# Altium schematic sheet AS025-HVPAK PMOD.SchDoc
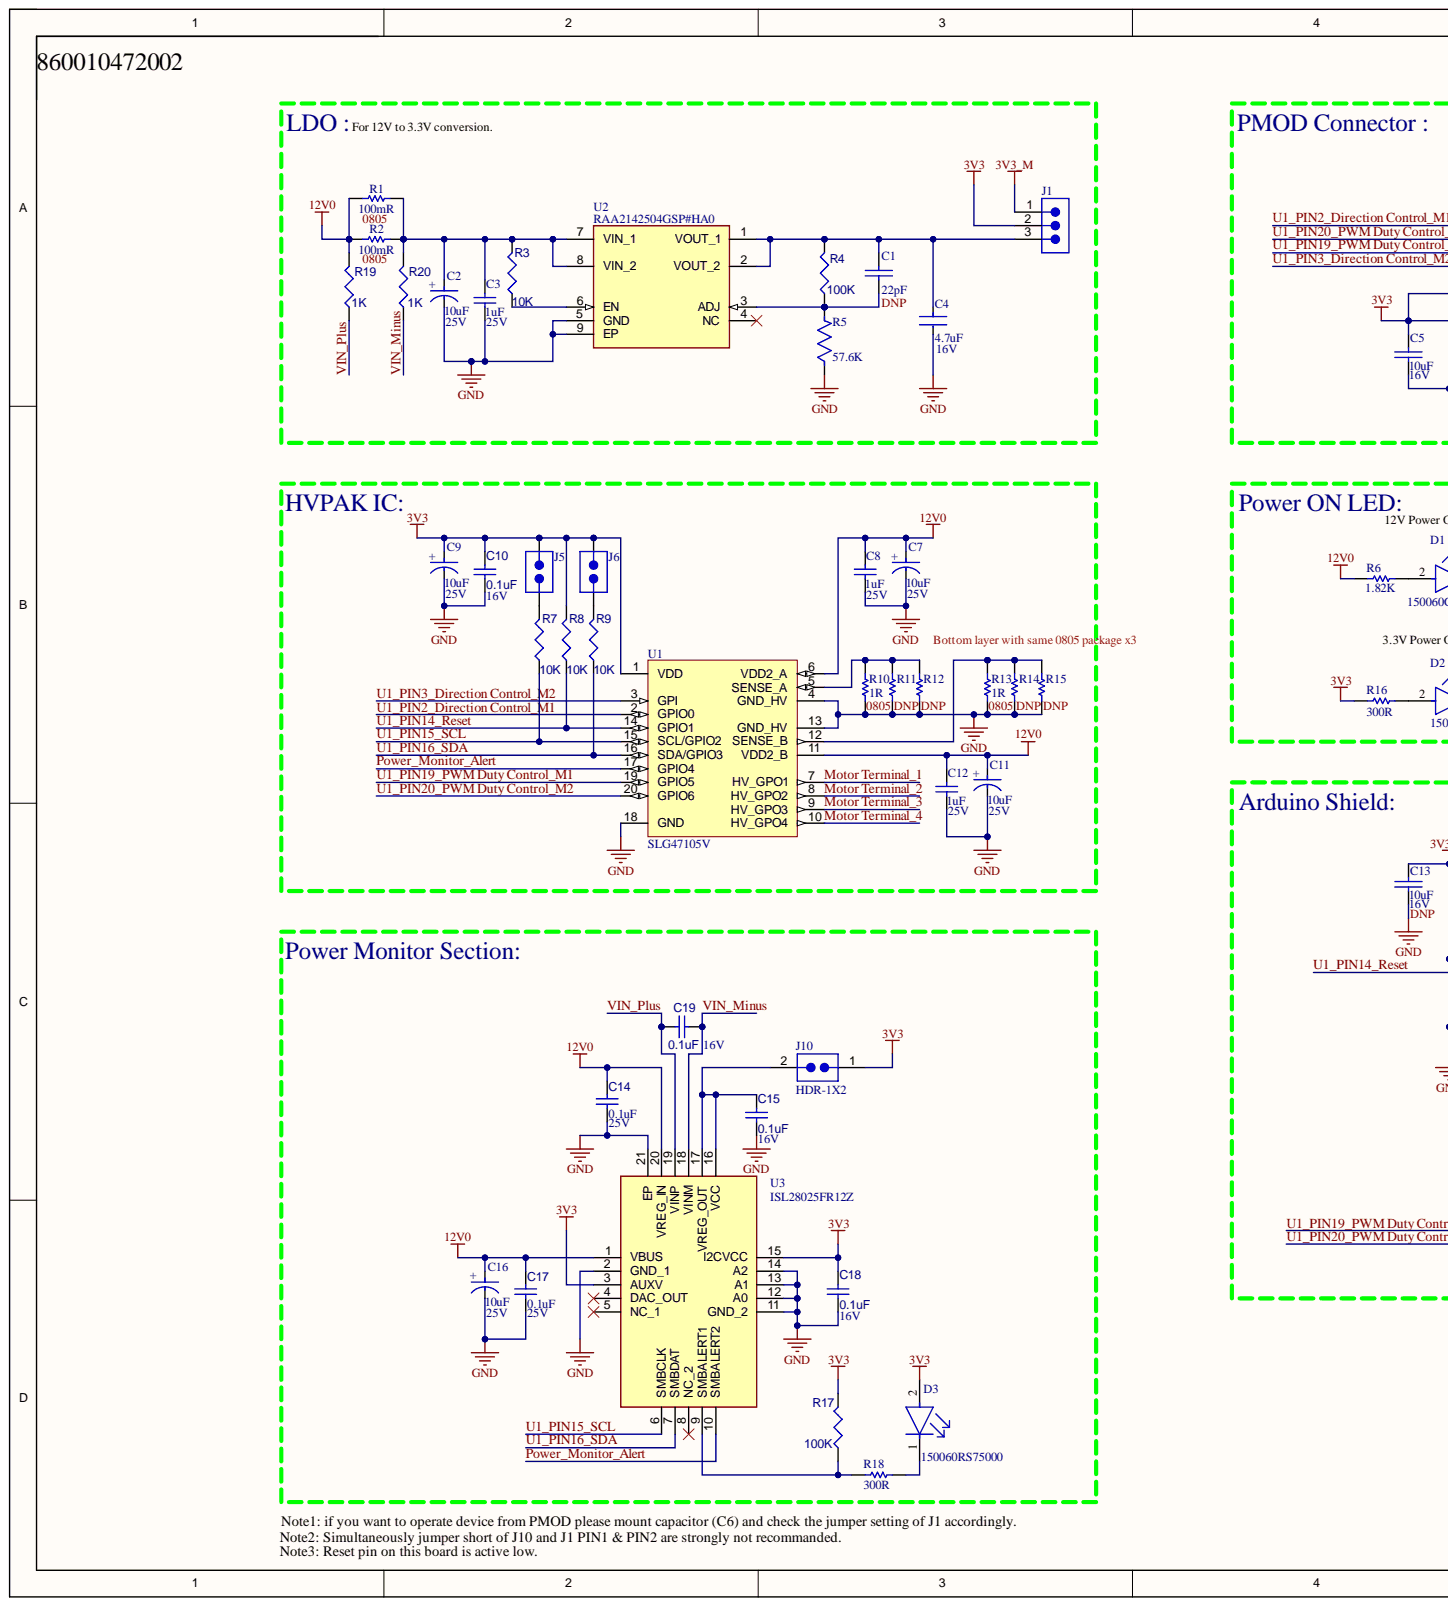
[diagram p0: AS025-HVPAK PMOD.SchDoc, left]
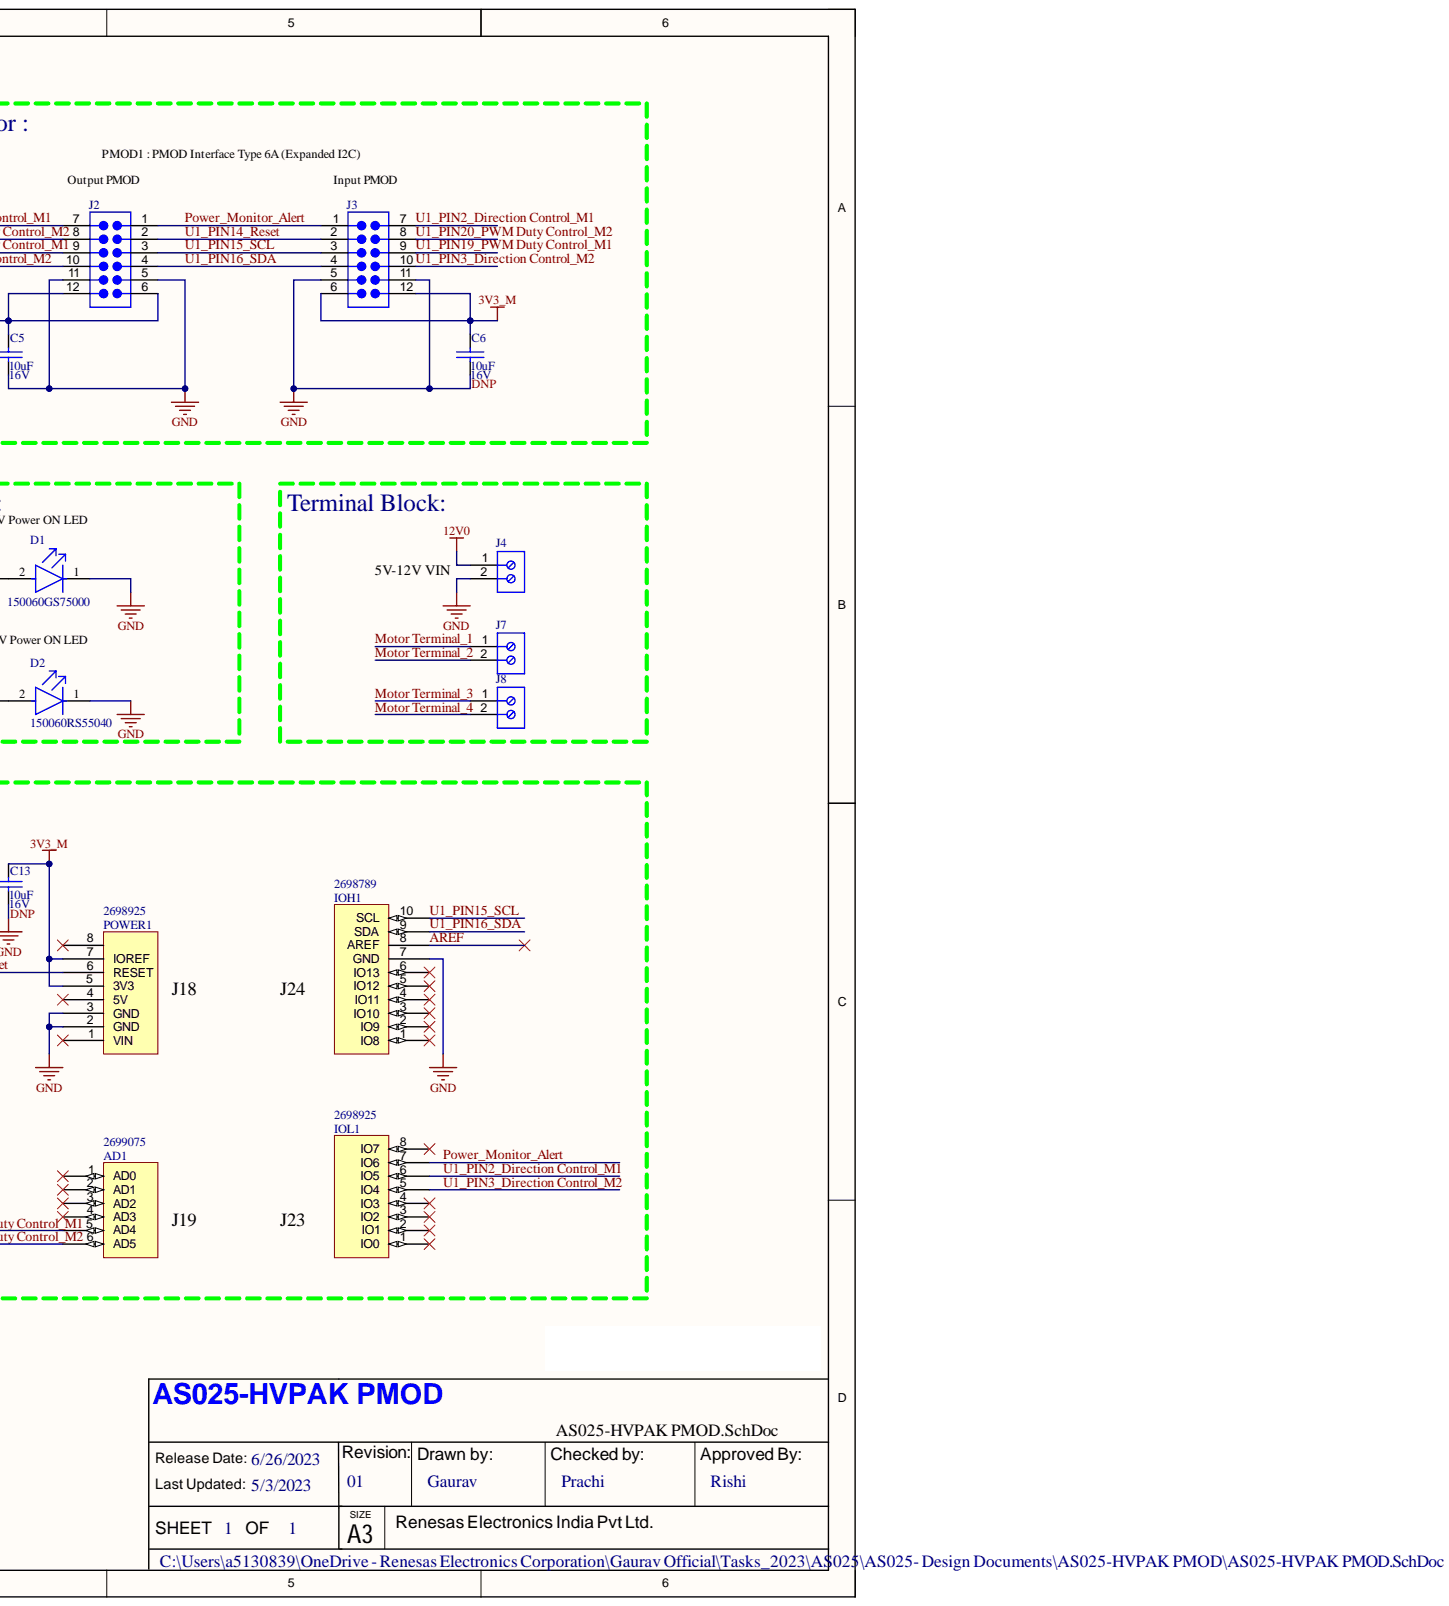
[diagram p1: AS025-HVPAK PMOD.SchDoc, right]

## Parts
AD1  AD  Supplier Part Number 4: 2699075  [Header 6x1 8.5mm]
C1  22pF  ASSY Note: DNP  [C0603]
C2  10uF  Rated DC Voltage (URdc): 25V  [FP-SC-MFG]
C3  1uF  Voltage Rating: 25V  [CAPC1608X90X40ML10T20]
C4  4.7uF  Voltage: 16V  [FP-C1608-080-0_1-IPC_C]
C5  10uF  Voltage Rating: 16V  [CAPC1608X10N]
C6  10uF  ASSY Note: DNP  Voltage Rating: 16V  [CAPC1608X10N]
C7  10uF  Rated DC Voltage (URdc): 25V  [FP-SC-MFG]
C8  1uF  Voltage Rating: 25V  [CAPC1608X90X40ML10T20]
C9  10uF  Rated DC Voltage (URdc): 25V  [FP-SC-MFG]
C10  0.1uF  Voltage Rating: 16V  [CAPC1608X90X35LL15T15]
C11  10uF  Rated DC Voltage (URdc): 25V  [FP-SC-MFG]
C12  1uF  Voltage Rating: 25V  [CAPC1608X90X40ML10T20]
C13  10uF  ASSY Note: DNP  Voltage Rating: 16V  [CAPC1608X10N]
C14  value 0.1uF  Voltage Rating: 25V  [CAPC1608X90X35LL15T15]  (hidden comment: CC0603KPX7R8BB104)
C15  0.1uF  Voltage Rating: 16V  [CAPC1608X90X35LL15T15]
C16  10uF  Rated DC Voltage (URdc): 25V  [FP-SC-MFG]
C17  value 0.1uF  Voltage Rating: 25V  [CAPC1608X90X35LL15T15]  (hidden comment: CC0603KPX7R8BB104)
C18  0.1uF  Voltage Rating: 16V  [CAPC1608X90X35LL15T15]
C19  0.1uF  Voltage Rating: 16V  [CAPC1608X90X35LL15T15]
D1  150060GS75000  [FP-150060GS75000-MFG]
D2  150060RS55040  [FP-150060RS55040-MFG]
D3  150060RS75000  [FP-150060RS75000-MFG]
IOH1  IOH  Supplier Part Number 3: 2698789  [Header 10x1 8.5mm]
IOL1  IOL  Supplier Part Number 4: 2698925  [Header 8x1 8.5mm]
J1  CON-000056-02  [FCI_77311-818-03LF]  (value not drawn)
J2  CON-000050-01_P  [SAMTEC_SSW-106-02-F-D-RA-PMOD]  (value not drawn)
J3  PMOD_6X2_RA_TH_Header  [PMOD-CONN2X6-RA-TH-HDR]  (value not drawn)
J4  691210910002  [691210910002]  (value not drawn)
J5  HDR-1X2  [HDR-1X2-FTS]  (value not drawn)
J6  HDR-1X2  [HDR-1X2-FTS]  (value not drawn)
J7  691210910002  [691210910002]  (value not drawn)
J8  691210910002  [691210910002]  (value not drawn)
J10  HDR-1X2  [HDR-1X2-FTS]
POWER1  Supplier Part Number 4: 2698925  [Header 8x1 8.5mm]  (hidden comment: POWER_UNO)
R1  100mR  [RESC2013X70X40LL20T20]
R2  100mR  Case/Package: 0805  [RESC2013X70X40LL20T20]
R3  10K  [RESC1608X55X25ML10T15]
R4  100K  [RESC1608X55X25ML10T15]
R5  57.6K  [FP-RT0603-MFG]
R6  1.82K  [RESC1608X55X25NL10T15]
R7  10K  [RESC1608X55X25ML10T15]
R8  10K  [RESC1608X55X25ML10T15]
R9  10K  [RESC1608X55X25ML10T15]
R10  1R  Case/Package: 0805  [RESC2013X60X35NL10T20]
R11  1R  ASSY Note: DNP  Case/Package: 0805  [RESC2013X60X35NL10T20]
R12  1R  ASSY Note: DNP  [RESC2013X60X35NL10T20]
R13  1R  Case/Package: 0805  [RESC2013X60X35NL10T20]
R14  1R  ASSY Note: DNP  Case/Package: 0805  [RESC2013X60X35NL10T20]
R15  1R  ASSY Note: DNP  Case/Package: 0805  [RESC2013X60X35NL10T20]
R16  300R  [RESC1608X55X25LL10T15]
R17  100K  [RESC1608X55X25ML10T15]
R18  300R  [RESC1608X55X25LL10T15]
R19  1K  [RESC1608X55X25ML10T15]
R20  1K  [RESC1608X55X25ML10T15]
U1  SLG47105V  [STQFN-20-2.0X3.0-C]
U2  RAA2142504GSP#HA0  [RAA2142504GSPHA0]
U3  ISL28025FR12Z  [QFN50P400X400X100-21N - duplicate]

## Nets
3V3: C5.1 C9.2 C10.2 C18.2 D3.2 J1.2 J2.6 J2.12 J5.1 J6.1 J10.1 R8.2 R16.1 R17.2 U1.1(VDD) U3.3(AUXV) U3.15(I2CVCC)
3V3_M: C6.1 C13.1 J1.1 J3.6 J3.12 POWER1.5(3V3) POWER1.7(IOREF)
12V0: C7.2 C8.2 C11.2 C12.2 C14.2 C16.2 C17.2 J4.1 R1.1 R2.1 R6.1 R19.2 U1.6(VDD2_A) U1.11(VDD2_B) U3.1(VBUS) U3.20(VREG_IN)
GND: C2.1 C3.1 C4.1 C5.2 C6.2 C7.1 C8.1 C9.1 C10.1 C11.1 C12.1 C13.2 C14.1 C15.1 C16.1 C17.1 C18.1 D1.1 D2.1 IOH1.7(GND) J2.5 J2.11 J3.5 J3.11 J4.2 POWER1.2(GND) POWER1.3(GND) R5.1 R10.1 R11.1 R12.1 R13.1 R14.1 R15.1 U1.4(GND_HV) U1.13(GND_HV) U1.18(GND) U2.5(GND) U2.9(EP) U3.2(GND_1) U3.11(GND_2) U3.12(A0) U3.13(A1) U3.14(A2) U3.21(EP)
AREF: IOH1.8(AREF)
Motor Terminal_1: J7.1 U1.7(HV_GPO1)
Motor Terminal_2: J7.2 U1.8(HV_GPO2)
Motor Terminal_3: J8.1 U1.9(HV_GPO3)
Motor Terminal_4: J8.2 U1.10(HV_GPO4)
Power_Monitor_Alert: IOL1.7(IO6) J2.1 J3.1 U1.17(GPIO4) U3.10(SMBALERT2)
U1_PIN2_Direction Control_M1: IOL1.6(IO5) J2.7 J3.7 U1.2(GPIO0)
U1_PIN3_Direction Control_M2: IOL1.5(IO4) J2.10 J3.10 U1.3(GPI)
U1_PIN14_Reset: J2.2 J3.2 POWER1.6(RESET) R8.1 U1.14(GPIO1)
U1_PIN15_SCL: IOH1.10(SCL) J2.3 J3.3 R7.1 U1.15(SCL/GPIO2) U3.6(SMBCLK)
U1_PIN16_SDA: IOH1.9(SDA) J2.4 J3.4 R9.1 U1.16(SDA/GPIO3) U3.7(SMBDAT)
U1_PIN19_PWM Duty Control_M1: AD1.5(AD4) J2.9 J3.9 U1.19(GPIO5)
U1_PIN20_PWM Duty Control_M2: AD1.6(AD5) J2.8 J3.8 U1.20(GPIO6)
VIN_Minus: C19.1 R20.1 U3.18(VINM)
VIN_Plus: C19.2 R19.1 U3.19(VINP)
NetC1_1: C1.1 C4.2 J1.3 R4.2 U2.1(VOUT_1) U2.2(VOUT_2)
NetC1_2: C1.2 R4.1 R5.2 U2.3(ADJ)
NetC2_2: C2.2 C3.2 R1.2 R2.2 R3.2 R20.2 U2.7(VIN_1) U2.8(VIN_2)
NetC15_2: C15.2 J10.2 U3.16(VCC) U3.17(VREG_OUT)
NetD1_2: D1.2 R6.2
NetD2_2: D2.2 R16.2
NetD3_1: D3.1 R18.2
NetJ5_2: J5.2 R7.2
NetJ6_2: J6.2 R9.2
NetR3_1: R3.1 U2.6(EN)
NetR10_2: R10.2 R11.2 R12.2 U1.5(SENSE_A)
NetR13_2: R13.2 R14.2 R15.2 U1.12(SENSE_B)
NetR17_1: R17.1 R18.1 U3.9(SMBALERT1)

Not connected: AD1 1(AD0) 2 3(AD2) 4(AD3); IOH1 1(IO8) 2(IO9) 3(IO10) 4(IO11) 5(IO12) 6(IO13); IOL1 1(IO0) 2(IO1) 3(IO2) 4(IO3) 8(IO7); POWER1 1(VIN) 4(5V) 8; U2 4(NC); U3 4(DAC_OUT) 5(NC_1) 8(NC_2)

## Library data of the parts
AD1 = AD: 6 Position Receptacle Connector 0.100" (2.54mm) Through Hole Gold; Manufacturer 1: Samtec; Manufacturer Part Number 1: SSQ-106-03-G-S; Case/Package: TH (6-POS, P-2.54mm); Component Kind: Standard; Library Name: Header.SchLib; Supplier 1: Digi-Key; Supplier 2: Arrow; Supplier 3: Arrow; Supplier 4: Arrow; Supplier Part Number 1: SAM1198-06-ND; Supplier Part Number 2: 2699089; Supplier Part Number 3: 2699088
C1 = CMP-043-000029-2: Capacitor, 22pF, 25V, SM 0603; Manufacturer 1: Kemet; Manufacturer Part Number 1: C0603C220K3GACTU; Detailed Description: CAP CER 22PF 25V C0G/NP0 0603; Case/Package: 0603; Component Kind: Standard; ComponentLink1Description: Digi-key Part Page; ComponentLink1URL: https://www.digikey.com/en/products/detail/kemet/C0603C180K3GACTU/2200061; Design Item ID: CI-22pF-25V-COG-NPO-SR-KMT; Library Name: Renesas Global; Part: 22pF, 25V; Revision: 1; Source: R_capacitors.SchLib; Type: Capacitor
C2 C7 C9 C11 C16 = CMP-05427-002131-1: CAP ALUM 10UF 20% 25V SMD; Manufacturer 1: Panasonic; Manufacturer Part Number 1: EEE-1EA100SR; Capacitor Type: Aluminium; Lead Length: 2.2mm; Leakage Current: 3uA; Life (Hours): 2000Hour; Polarity: Polarized; Ripple Current: 28mA; Tan Delta: 0.14; Capacitance: 10uF; Case/Package: Radial; Category: Aluminum Electrolytic Capacitors; Component Kind: Standard; ComponentLink1Description: https://datasheet.ciiva.com:443/pdfs/VipMasterIC/IC/PANA/PANA-S-A0009615203/PANA-S-A0009615203-1.pdf?src-supplier=IHS+Markit; ComponentLink1URL: https://datasheet.ciiva.com:443/pdfs/VipMasterIC/IC/PANA/PANA-S-A0009615203/PANA-S-A0009615203-1.pdf?src-supplier=IHS+Markit; ComponentLink2Description: https://datasheet.ciiva.com/11778/0900766b8078636e-11778389.pdf?src-supplier=Rs; ComponentLink2URL: https://datasheet.ciiva.com/11778/0900766b8078636e-11778389.pdf?src-supplier=Rs; ComponentLink3Description: https://datasheet.ciiva.com/24069/aba0000ce2-40801-24069004.pdf?src-supplier=Mouser; ComponentLink3URL: https://datasheet.ciiva.com/24069/aba0000ce2-40801-24069004.pdf?src-supplier=Mouser; ComponentLink4Description: https://datasheet.ciiva.com/14604/70255710-14604074.pdf?src-supplier=Allied+Electronics; ComponentLink4URL: https://datasheet.ciiva.com/14604/70255710-14604074.pdf?src-supplier=Allied+Electronics; ComponentLink5Description: https://datasheet.ciiva.com/14599/70255710-14599433.pdf?src-supplier=Allied+Electronics; ComponentLink5URL: https://datasheet.ciiva.com/14599/70255710-14599433.pdf?src-supplier=Allied+Electronics; ComponentLink6Description: https://datasheet.ciiva.com/43485/aba0000ce2-43485611.pdf?src-supplier=Farnell; ComponentLink6URL: https://datasheet.ciiva.com/43485/aba0000ce2-43485611.pdf?src-supplier=Farnell; ComponentLink7Description: https://datasheet.ciiva.com/16432/aba0000ce2-16432888.pdf?src-supplier=Future+Electronics; ComponentLink7URL: https://datasheet.ciiva.com/16432/aba0000ce2-16432888.pdf?src-supplier=Future+Electronics; ComponentLink8Description: https://datasheet.ciiva.com/2985/aba0000ce2-2985103.pdf?src-supplier=Avnet; ComponentLink8URL: https://datasheet.ciiva.com/2985/aba0000ce2-2985103.pdf?src-supplier=Avnet; ComponentLink9Description: https://datasheet.ciiva.com/30215/11aba0000ce2-30215179.pdf?src-supplier=Onlinecomponents.com; ComponentLink9URL: https://datasheet.ciiva.com/30215/11aba0000ce2-30215179.pdf?src-supplier=Onlinecomponents.com; Construction: Cylindrical; Contact Plating: Tin; Diameter: 5mm; Dielectric Material: Aluminium; Height: 5.4mm; Height - Seated (Max): 0.213inch; Lead Pitch: 1.5mm; Length: 5.5mm; Library Name: Altium Content Vault; Max Operating Temperature: 85°C; Min Operating Temperature: -40°C; Mount: Surface Mount; Negative Tolerance: 20%; Number of Pins: 2; Package Quantity: 1000; Package Shape: Cylindrical; Package Style: SMTMeter; Packaging: Tape and Reel; Positive Tolerance: 20%; Pricing 1: 1=0.39000, 10=0.26900, 50=0.18900, 100=0.16200, 1000=0.10200, 10000=0.10200 (USD); Radiation Hardening: No; Ratings: AEC-Q200; Reach SVHC Compliant: Yes; Ripple Current (AC): 28mA; RoHS: Yes; RoHS Compliant: Yes; Series: S; Size Code: 2121; Stock 1: 157; Supplier 1: Mouser; Supplier Part Number 1: 667-EEE-1EA100SR; Termination: SMD/SMT; Tolerance: 20%; Voltage: 25V; Voltage Rating: 25V; Voltage Rating (DC): 25V; Width: 5.3mm
C3 C8 C12 = CMP-001-00005-1: 1 µF ±10% 25V Ceramic Capacitor X7R 0603 (1608 Metric); Manufacturer 1: Yageo; Manufacturer Part Number 1: CC0603KRX7R8BB105; Capacitance: 1µF; Capacitor Type: Ceramic; Case/Package: 0603; Component Kind: Standard; Library Name: Renesas Global; Max Operating Temperature: 125°C; Min Operating Temperature: -55°C; Mounting Technology: SM; RoHS Compliant: True; Tolerance: 10%
C4 = CMP-2000-04981-2: Multilayer Ceramic Capacitors 4.7μF ±10% 16V X5R SMD 0603; Manufacturer 1: TDK Corporation; Manufacturer Part Number 1: C1608X5R1C475K080AC; Capacitance: 4.7uF; Case Code (Imperial): 0603; Case Code (Metric): 1608; Case/Package: 0603; Component Kind: Standard; Construction: Flat; Depth: 0.8mm; Dielectric: X5R; Dielectric Material: Ceramic; Height: 0.8mm; Lead Free: Lead Free; Length: 1.6mm; Library Name: Altium Content Vault; Max Operating Temperature: 85°C; Min Operating Temperature: -55°C; Mount: Surface Mount; Package Quantity: 1; Packaging: Tape and Reel; REACH SVHC: No SVHC; RoHS Compliant: Yes; Series: C; Termination: SMD/SMT; Thickness: 0.035inch; Tolerance: 10%; Voltage Rating: 16V; Voltage Rating (DC): 16V; Weight: 7.1E-05oz; Width: 0.031inch
C5 C6 C13 = CMP-043-000020-2: 10 µF ±10% 16V Ceramic Capacitor X5R 0603 (1608 Metric); Manufacturer 1: Yageo; Manufacturer Part Number 1: CC0603KRX5R7BB106; Detailed Description: Capacitor, 10uF, 16V, SM 0603, Multilayer Ceramic, X5R, RoHS; Case/Package: 0603; Component Kind: Standard; Design Item ID: CI-10uF-16V-X5R-SR-MRT; Library Name: Renesas Global; Package: 0603; Part: 10uF; Revision: 1; Source: R_capacitors.SchLib; Tolerance: 10%; Type: Capacitor
C10 C15 C18 C19 = CMP-002-000055-1: 0.1 µF ±10% 16V Ceramic Capacitor X7R 0603 (1608 Metric); Manufacturer 1: YAGEO; Manufacturer Part Number 1: CC0603KPX7R7BB104; Capacitor Type: Ceramic; Case/Package: 0603; Component Kind: Standard; Design Item ID: CC0603KPX7R7BB104; Library Name: Renesas Global; Max Operating Temperature: 125°C; Min Operating Temperature: -55°C; Mounting Technology: SM; Pins: 2; RoHS Compliant: True; Tolerance: 10%; Value: 0.1uF; Source: RAA211250 SYNC BUCK REGULATOR.SchLib
C14 C17 = CMP-002-000056-1: 0.1 µF ±10% 25V Ceramic Capacitor X7R 0603 (1608 Metric); Manufacturer 1: YAGEO; Capacitor Type: Ceramic; Case/Package: 0603; Component Kind: Standard; Design Item ID: CC0603KPX7R8BB104; Library Name: Renesas Global; Manufacturer Part Number 1: CC0603KPX7R8BB104; Max Operating Temperature: 125°C; Min Operating Temperature: -55°C; Mounting Technology: SM; Pins: 2; RoHS Compliant: True; Tolerance: 10%; Source: RAA211250 SYNC BUCK REGULATOR.SchLib
D1 = CMP-1426-00002-2: LED GREEN CLEAR 0603 SMD; Manufacturer 1: Wurth Electronics; Dominant Wavelength: 520nm; Forward Current: 30mA; Forward Voltage: 3.2V; Lens Color: Colorless; Lens Size: 0.7mm; Luminous Intensity: 430mcd; Number of Functions: 1; Optoelectronic Device Type: SINGLE COLOR LED; Shape: Rectangular; Test Current: 20mA; Viewing Angle: 140°; Case Code (Imperial): 0603; Case Code (Metric): 1608; Case/Package: 0603; Category: LEDs; Color: Green; Component Kind: Standard; ComponentLink1Description: https://datasheet.ciiva.com:443/pdfs/VipMasterIC/IC/MIDC/MIDC-S-A0007790169/MIDC-S-A0007790169-1.pdf?src-supplier=IHS+Markit; ComponentLink1URL: https://datasheet.ciiva.com:443/pdfs/VipMasterIC/IC/MIDC/MIDC-S-A0007790169/MIDC-S-A0007790169-1.pdf?src-supplier=IHS+Markit; ComponentLink2Description: https://datasheet.ciiva.com/29562/150060gs75000-29562868.pdf?src-supplier=Digi-Key; ComponentLink2URL: https://datasheet.ciiva.com/29562/150060gs75000-29562868.pdf?src-supplier=Digi-Key; ComponentLink3Description: https://datasheet.ciiva.com/29279/759427-da-01-en-chipled-0603-w-kl-gruen-150060gs75000-29279201.pdf?src-supplier=Conrad; ComponentLink3URL: https://datasheet.ciiva.com/29279/759427-da-01-en-chipled-0603-w-kl-gruen-150060gs75000-29279201.pdf?src-supplier=Conrad; ComponentLink4Description: https://datasheet.ciiva.com/29562/150060gs75000-29562869.pdf?src-supplier=Digi-Key; ComponentLink4URL: https://datasheet.ciiva.com/29562/150060gs75000-29562869.pdf?src-supplier=Digi-Key; ComponentLink5Description: https://datasheet.ciiva.com/29562/150060gs75000-29562870.pdf?src-supplier=Digi-Key; ComponentLink5URL: https://datasheet.ciiva.com/29562/150060gs75000-29562870.pdf?src-supplier=Digi-Key; Forward Current-Max: 30mA; Height: 0.8mm; Lead Free: Lead Free; Length: 1.6mm; Lens Style: Clear; Lens Transparency: Clear; Library Name: Altium Content Vault; Luminous Intensity-Nom: 430mcd; Manufacturer Part Number 1: 150060GS75000; Max Operating Temperature: 85°C; Min Operating Temperature: -40°C; Mount: Surface Mount; Number of Pins: 2; Overall Height: 0.7mm; Package Quantity: 1; Packaging: Cut Tape; Pricing 1: 100=0.00107, 500=0.08300, 1000=0.07900, 2000=0.07500, 4000=0.07100 (GBP); Reach SVHC Compliant: Yes; RoHS: Yes; RoHS Compliant: Yes; Series: WL-SMCW; Size: 1.1mm; Stock 1: 12800; Supplier 1: Rs; Supplier Part Number 1: 8154209; Terminal Pitch: 1.6mm; Wavelength: 520nm; Width: 0.8mm
D2 = CMP-207942-000007-1: LED RED DIFFUSED 0603 SMD; Manufacturer 1: Würth Elektronik; Manufacturer Part Number 1: 150060YS55040; Dominant Wavelength: 624nm; Forward Voltage: 2V; Lens Size: 0.8mm; Lens Style: Flat; Luminous Intensity: 90mcd; Test Current: 20mA; Viewing Angle: 140°; Case Code (Imperial): 0603; Case Code (Metric): 1608; Case/Package: 0603; Color: Red; Component Kind: Standard; Height: 0.5mm; Length: 1.6mm; Lens Transparency: Diffused; Library Name: Altium Content Vault; Mount: Surface Mount; Packaging: Cut Tape; RoHS Compliant: No; Width: 0.8mm
D3 = CMP-1426-00001-2: LED RED CLEAR 0603 SMD; Manufacturer 1: Wurth Electronics; Dominant Wavelength: 625nm; Forward Current: 30mA; Forward Voltage: 2V; Lens Color: Colorless; Lens Size: 0.7mm; Luminous Intensity: 250mcd; Test Current: 20mA; Viewing Angle: 140°; Case Code (Imperial): 0603; Case Code (Metric): 1608; Case/Package: 0603; Color: Red; Component Kind: Standard; Height: 0.8mm; Length: 1.6mm; Lens Style: Clear; Lens Transparency: Clear; Library Name: Altium Content Vault; Manufacturer Part Number 1: 150060RS75000; Max Operating Temperature: 85°C; Min Operating Temperature: -40°C; Mount: Surface Mount; Package Quantity: 1; Packaging: Cut Tape; RoHS Compliant: Yes; Series: WL-SMCW; Wavelength: 625nm; Width: 0.8mm
IOH1 = IOH: 10 Position Receptacle Connector 0.100" (2.54mm) Through Hole Gold; Manufacturer 1: Samtec; Manufacturer Part number 1: SSQ-110-03-G-S; Case/Package: TH (10-POS, P-2.54mm); Component Kind: Standard; Library Name: Header.SchLib; Supplier 1: Digi-Key; Supplier 2: Arrow; Supplier 3: Arrow; Supplier Part Number 1: SAM1198-10-ND; Supplier Part Number 2: 2698803S4475693N1004
IOL1 = IOL: 8 Position Receptacle Connector 0.100" (2.54mm) Through Hole Gold; Manufacturer 1: Samtec; Manufacturer Part Number 1: SSQ-108-03-G-S; Case/Package: TH (8-POS, P-2.54mm); Component Kind: Standard; Library Name: Header.SchLib; Supplier 1: Digi-Key; Supplier 2: Arrow; Supplier 3: Arrow; Supplier 4: Arrow; Supplier Part Number 1: SAM1198-08-ND; Supplier Part Number 2: 2698939; Supplier Part Number 3: 2698940
J1 = CMP-038-000018-1: Connector Header Through Hole 3 position 0.100" (2.54mm); Manufacturer: FCI; Manufacturer Part Number 1: 77311-818-03LF; Positions: 3; Case/Package: TH (3 POS P-2.54mm); Component Kind: Standard; Design Item ID: CON-000056-02; Library Name: Renesas Global; Manufacturer 1: FCI; Mfg: FCI; Mfg Part Number: 77311-818-03LF; Pitch: 2.54mm; PN: 77311-818-03LF; Source: Connectors.SchLib
J2 = CMP-038-000217-1: 12 Position Receptacle Connector 0.100" (2.54mm) Through Hole, Right Angle Gold; Manufacturer 1: Würth Elektronik; Manufacturer Part Number 1: 613012243121; Mfg Part Number: SSW-106-02-F-D-RA; Positions: 12; Case/Package: TH (P-2.54x2.54mm); Component Kind: Standard; Design Item ID: CON-000050-01_P; Library Name: Renesas Global; Manufacturer 2: Samtec; Manufacturer Part Number 2: SSW-106-02-F-D-RA; Pitch: 2.54mm; Source: Connectors.SchLib
J3 = CMP-024-000000-1: Connector Header Through Hole, Right Angle 12 position 0.100" (2.54mm); Manufacturer 1: Würth Elektronik; Manufacturer Part Number 1: 61301221021; Positions: 12; Case/Package: TH (P-2.54x2.54mm); Component Kind: Standard; Design Item ID: PMOD_6X2_RA_TH_Header; Library Name: Renesas Global; Manufacturer 2: Harwin; Manufacturer 3: Samtec Inc.; Manufacturer Part Number 2: M20-9950645; Manufacturer Part Number 3: TSW-106-08-F-D-RA; Pitch: 2.54mm; Source: PMOD_Conn.SchLib
J4 J7 J8 = CMP-1502-02456-1: Serie 2109 - 2.54 mm Horizontal Entry with Rising Cage Clamp WR-TBL, 2 pin; Manufacturer 1: Wurth Elektronik; Manufacturer Series: 2109; Pin Number: 2; Pitch: 2.54mm; Category: Terminal Blocks; Component Kind: Standard; ComponentLink1Description: https://datasheet.ciiva.com:443/pdfs/VipMasterIC/IC/MIDC/MIDCS07477/MIDCS07477-1.pdf?src-supplier=IHS+Markit; ComponentLink1URL: https://datasheet.ciiva.com:443/pdfs/VipMasterIC/IC/MIDC/MIDCS07477/MIDCS07477-1.pdf?src-supplier=IHS+Markit; Datasheet URL: http://katalog.we-online.de/em/datasheet/6912109100xx.pdf; Library Name: Altium Content Vault; Manufacturer Part Number 1: 691210910002; Manufacturer URL: http://www.we-online.de; Mounting Technology: THT; Operating Temperature: -40to105degC; Package Reference: 691210910002; Packaging: Box; Part Number: 691210910002; Pricing 1: 1=1.14000, 10=1.04300, 50=0.98400, 100=0.90500, 500=0.74800 (USD); Reach SVHC Compliant: Yes; RoHS: Yes; RoHS Compliant: Yes; Stock 1: 1283; Supplier 1: Digi-Key; Supplier Part Number 1: 732-691210910002-ND; Terminal and Terminal Block Type: BARRIER STRIP TERMINAL BLOCK; Publisher: WurthElektronik
J5 J6 J10 = CMP-006-000000-1: CONN HEADER VERT 2POS 1.27 MM; Manufacturer 1: Samtec Inc.; Manufacturer Part Number 1: FTS-102-01-L-S; Pitch: 1.27 mm; Case/Package: TH (P-1.27mm); Component Kind: Standard; ComponentLink1Description: Datasheet; ComponentLink1URL: http://suddendocs.samtec.com/catalog_english/fts.pdf; ComponentLink2Description: Package Specification; ComponentLink2URL: http://suddendocs.samtec.com/prints/fts-1xx-xx-x-sx-mkt.pdf; Design Item ID: HDR-1X2; Library Name: Renesas Global; Mounting Technology: Through Hole; Orientation: Vertical; PackageDescription: Shrouded Header; RoHS: True; Source: R_Misc_Conn.SchLib; Supplier 1: Digi-Key; Supplier Part Number 1: FTS-102-01-L-S-ND; Temperature Range: -55 to 125 degC
POWER1 = POWER_UNO: 8 Position Receptacle Connector 0.100" (2.54mm) Through Hole Gold; Manufacturer 1: Samtec; Manufacturer Part Number 1: SSQ-108-03-G-S; Case/Package: TH (8-POS, P-2.54mm); Component Kind: Standard; Library Name: Header.SchLib; Supplier 1: Digi-Key; Supplier 2: Arrow; Supplier 3: Arrow; Supplier 4: Arrow; Supplier Part Number 1: SAM1198-08-ND; Supplier Part Number 2: 2698939; Supplier Part Number 3: 2698940
R1 R2 = CMP-2001-00503-1: 100 mOhms ±1% 0.333W, 1/3W Chip Resistor 0805 (2012 Metric) Automotive AEC-Q200, Current Sense Thick Film; Manufacturer: Panasonic; Manufacturer Part Number: ERJ6BSFR10V; Rated Power Dissipation (P): 330mW; Rated Temperature: 70°C; Temperature Coefficient: 250ppm/°C; Category: Chip SMD Resistors; Component Kind: Standard; ComponentLink1Description: https://datasheet.ciiva.com:443/pdfs/VipMasterIC/IC/PANA/PANA-S-A0009615198/PANA-S-A0009615198-1.pdf?src-supplier=IHS+Markit; ComponentLink1URL: https://datasheet.ciiva.com:443/pdfs/VipMasterIC/IC/PANA/PANA-S-A0009615198/PANA-S-A0009615198-1.pdf?src-supplier=IHS+Markit; ComponentLink2Description: https://datasheet.ciiva.com/11818/0900766b80eefa12-11818538.pdf?src-supplier=Rs; ComponentLink2URL: https://datasheet.ciiva.com/11818/0900766b80eefa12-11818538.pdf?src-supplier=Rs; ComponentLink3Description: https://datasheet.ciiva.com/48535/erj-eng-tds-48535045.pdf?src-supplier=Distrelec; ComponentLink3URL: https://datasheet.ciiva.com/48535/erj-eng-tds-48535045.pdf?src-supplier=Distrelec; ComponentLink4Description: https://datasheet.ciiva.com/6137/391509-6137607.pdf?src-supplier=Farnell; ComponentLink4URL: https://datasheet.ciiva.com/6137/391509-6137607.pdf?src-supplier=Farnell; ComponentLink5Description: https://datasheet.ciiva.com/7944/391509-7944654.pdf?src-supplier=Element14; ComponentLink5URL: https://datasheet.ciiva.com/7944/391509-7944654.pdf?src-supplier=Element14; ComponentLink6Description: https://datasheet.ciiva.com/6137/391509-6137624.pdf?src-supplier=Farnell; ComponentLink6URL: https://datasheet.ciiva.com/6137/391509-6137624.pdf?src-supplier=Farnell; ComponentLink7Description: https://datasheet.ciiva.com/7944/391509-7944655.pdf?src-supplier=Element14; ComponentLink7URL: https://datasheet.ciiva.com/7944/391509-7944655.pdf?src-supplier=Element14; ComponentLink8Description: https://datasheet.ciiva.com/24063/aoa0000ce3-72189-24063962.pdf?src-supplier=Mouser; ComponentLink8URL: https://datasheet.ciiva.com/24063/aoa0000ce3-72189-24063962.pdf?src-supplier=Mouser; ComponentLink9Description: https://datasheet.ciiva.com/9186/aoa0000ce3-9186826.pdf?src-supplier=Avnet; ComponentLink9URL: https://datasheet.ciiva.com/9186/aoa0000ce3-9186826.pdf?src-supplier=Avnet; JESD-609 Code: e3; Library Name: Altium Content Vault; Manufacturer 1: Panasonic; Manufacturer Part Number 1: ERJ6BSFR10V; Max Operating Temperature: 125°C; Min Operating Temperature: -55°C; Mount: Surface Mount; Number of Pins: 2; Package Shape: Rectangular; Packaging: Tape and Reel; Pricing 1: 5000=0.05170 (USD); Reach SVHC Compliant: Yes; Reference Standard: AEC-Q200; Resistance: 100mR; Resistor Type: FIXED RESISTOR; RoHS: Yes; RoHS Compliant: Yes; Stock 1: 15000; Supplier 1: Arrow Electronics; Supplier Part Number 1: ERJ-6BSFR10V; Technology: METAL GLAZE/THICK FILM; Terminal Finish: Tin; Termination: Wraparound; Tolerance: 1%
  R1: Case/Package: 0805
R3 R7-R9 = CMP-009-00017-5: Chip Resistor, 10 KOhm, +/- 1%, 01 W, -55 to 155 degC, 0603 (1608 Metric); Manufacturer 1: Yageo; Manufacturer Part Number 1: RC0603FR-0710KL; Power: 100mW; Voltage Rating: 50V; Case/Package: 0603; Component Kind: Standard; Library Name: Renesas Global; Max Operating Temperature: 155°C; Min Operating Temperature: -55°C; Mounting Technology: SM; Pins: 2; RoHS Compliant: True; Tolerance: 1%; Value: 10K
R4 = CMP-009-00023-5: Chip Resistor, 100 KOhm, +/- 1%, 0.1 W, -55 to 155 degC, 0603 (1608 Metric); Manufacturer 1: Yageo; Manufacturer Part Number 1: RC0603FR-07100KL; Power: 100mW; Voltage Rating: 50V; Case/Package: 0603; Component Kind: Standard; Library Name: Renesas Global; Max Operating Temperature: 155°C; Min Operating Temperature: -55°C; Mounting Technology: SM; Pins: 2; RoHS Compliant: True; Tolerance: 1%; Value: 100K
R5 = CMP-03412-013913-1: RES SMD 57.6K OHM 1% 1/10W 0603; Manufacturer: Yageo; Manufacturer Part Number 1: RT0603FRE0757K6L; Power Rating: 0.1W; Resistance: 57.6KΩ; Temperature Coefficient: 50 ppm/°C; Tolerance: 0.01; Value: 57.6KΩ; Voltage Rating: 75V; Automotive: No; Case/Package: 0603; Category: Res; Component Kind: Standard; ComponentLink2Description: Datasheet; ComponentLink2URL: http://www.yageo.com/documents/recent/PYu-RT_1-to-0.01_RoHS_L_9.pdf; Composition: Thin Film; Device Class L1: Passive Components; Device Class L2: Resistors; Device Class L3: Chip SMD Resistors; Digikey Description: RES SMD 57.6K OHM 1% 1/10W 0603; Footprint Url: http://www.yageo.com/exep/pages/download/literatures/PYu-R_Mount_10.pdf; Height: 0.55mm; Ipc Land Pattern Name: RESC16080X45; Lead Free: Yes; Library Name: Altium Content Vault; Manufacturer 1: Yageo; Mouser Description: Thin Film Resistors - SMD 1/10W 57.6K ohm 1% 50ppm; Octopart: https://octopart.com/rt0603fre0757k6l-yageo-1478888; Package: RT0603; RoHS: TRUE; Series: RT; Temperature: -55°C to +155°C; Temperature Range High: +155°C; Temperature Range Low: -55°C
R6 = CMP-006-000025-1: 1.82 kOhms ±1% 0.1W, 1/10W Chip Resistor 0603 (1608 Metric) Moisture Resistant Thick Film; Manufacturer 1: YAGEO; Manufacturer Part Number 1: RC0603FR-071K82L; Power: 100mW; Case/Package: 0603; Component Kind: Standard; Design Item ID: RC0603FR-071K82L; Library Name: Renesas Global; Max Operating Temperature: 155°C; Min Operating Temperature: -55°C; Mounting Technology: SM; Pins: 02; RoHS Compliant: Unknown; Tolerance: 1%; Value: 1.82K; Source: RAA211250 SYNC BUCK REGULATOR.SchLib
R10-R15 = CMP-1659-00014-4: Chip Resistor, 1 Ohm, +/- 1%, 125 mW, -55 to 155 degC, 0805 (2012 Metric), RoHS, Tape and Reel; Manufacturer 1: Yageo; Manufacturer Part Number 1: RC0805FR-071RL; Package Height: 0.5mm; Package Length: 2mm; Package Width: 1.25mm; Rated Power Dissipation (P): 125mW; Rated Temperature: 70°C; Temperature Coefficient: 200ppm/°C; Voltage Rating: 150 V; Working Voltage: 150V; Category: Chip SMD Resistors; Component Kind: Standard; ComponentLink1Description: Manufacturer URL; ComponentLink1URL: http://www.yageo.com/; ComponentLink2Description: Datasheet; ComponentLink2URL: http://www.yageo.com/documents/recent/PYu-RC_Group_51_RoHS_L_04.pdf; ComponentLink3Description: https://datasheet.ciiva.com:443/pdfs/VipMasterIC/IC/YAGO/YAGO-S-A0008145938/YAGO-S-A0008145938-1.pdf?src-supplier=IHS+Markit; ComponentLink3URL: https://datasheet.ciiva.com:443/pdfs/VipMasterIC/IC/YAGO/YAGO-S-A0008145938/YAGO-S-A0008145938-1.pdf?src-supplier=IHS+Markit; ComponentLink4Description: https://datasheet.ciiva.com/2459/pyu-r-int-thick-7-2459662.pdf?src-supplier=Digi-Key; ComponentLink4URL: https://datasheet.ciiva.com/2459/pyu-r-int-thick-7-2459662.pdf?src-supplier=Digi-Key; ComponentLink5Description: https://datasheet.ciiva.com/2459/pyu-r-int-thick-7-2459663.pdf?src-supplier=Digi-Key; ComponentLink5URL: https://datasheet.ciiva.com/2459/pyu-r-int-thick-7-2459663.pdf?src-supplier=Digi-Key; ComponentLink6Description: https://datasheet.ciiva.com/2459/pyu-r-int-thick-7-2459661.pdf?src-supplier=Digi-Key; ComponentLink6URL: https://datasheet.ciiva.com/2459/pyu-r-int-thick-7-2459661.pdf?src-supplier=Digi-Key; ComponentLink7Description: https://datasheet.ciiva.com/7941/444164-7941736.pdf?src-supplier=Element14; ComponentLink7URL: https://datasheet.ciiva.com/7941/444164-7941736.pdf?src-supplier=Element14; ComponentLink8Description: https://datasheet.ciiva.com/7941/444164-7941737.pdf?src-supplier=Element14; ComponentLink8URL: https://datasheet.ciiva.com/7941/444164-7941737.pdf?src-supplier=Element14; Construction: Chip; DatasheetVersion: Rev. 4, 02/2016; Height: 0.5 mm; JESD-609 Code: e3; Length: 2 mm; Library Name: Altium Content Vault; Max Operating Temperature: 155 degC; Min Operating Temperature: -55 degC; Mount: Surface Mount; Mounting Technology: Surface Mount; Number of Pins: 2; Package Quantity: 5000; Package Shape: Rectangular; Package Style: SMTMeter; PackageDescription: 2-Pin Surface Mounted Device, Body 2 x 1.25 mm; PackageReference: 0805; Packaging: Tape and Reel; Pins: 2; Pricing 1: 5000=0.00660, 20000=0.00570, 25000=0.00630, 100000=0.00540, 150000=0.00590, 200000=0.00530, 500000=0.00570, 625000=0.00500, 1500000=0.00530 (USD); REACH SVHC: No SVHC; Reach SVHC Compliant: Yes; Resistance: 1R; Resistor Type: FIXED RESISTOR; RoHS: Yes; RoHS Compliant: Yes; RoHSCompliant: true; Size Code: 0805; Stock 1: 1585000; Supplier 1: Future Electronics; Supplier Part Number 1: 4166567; Technology: METAL GLAZE/THICK FILM; Terminal Finish: Nickel; Termination: Wraparound; Tolerance: 1%
  R12: Case/Package: 0805
R16 R18 = CMP-2000-04000-1: 300 Ohms ±1% 0.1W, 1/10W Chip Resistor 0603 (1608 Metric) Moisture Resistant Thick Film; Manufacturer 1: Yageo; Manufacturer Part Number 1: RC0603FR-07300RL; Package Height: 0.45mm; Package Length: 1.6mm; Package Width: 0.8mm; Rated Power Dissipation (P): 100mW; Rated Temperature: 70°C; Temperature Coefficient: 100ppm/°C; Working Voltage: 75V; Case/Package: 0603; Category: Chip SMD Resistors; Component Kind: Standard; ComponentLink1Description: https://datasheet.ciiva.com:443/pdfs/VipMasterIC/IC/YAGO/YAGO-S-A0008145938/YAGO-S-A0008145938-1.pdf?src-supplier=IHS+Markit; ComponentLink1URL: https://datasheet.ciiva.com:443/pdfs/VipMasterIC/IC/YAGO/YAGO-S-A0008145938/YAGO-S-A0008145938-1.pdf?src-supplier=IHS+Markit; ComponentLink2Description: https://datasheet.ciiva.com/46395/443971-46395002.pdf?src-supplier=Element14; ComponentLink2URL: https://datasheet.ciiva.com/46395/443971-46395002.pdf?src-supplier=Element14; ComponentLink3Description: https://datasheet.ciiva.com/2454/pyu-r-int-thick-7-2454628.pdf?src-supplier=Digi-Key; ComponentLink3URL: https://datasheet.ciiva.com/2454/pyu-r-int-thick-7-2454628.pdf?src-supplier=Digi-Key; ComponentLink4Description: https://datasheet.ciiva.com/2454/pyu-r-int-thick-7-2454629.pdf?src-supplier=Digi-Key; ComponentLink4URL: https://datasheet.ciiva.com/2454/pyu-r-int-thick-7-2454629.pdf?src-supplier=Digi-Key; ComponentLink5Description: https://datasheet.ciiva.com/2454/pyu-r-int-thick-7-2454627.pdf?src-supplier=Digi-Key; ComponentLink5URL: https://datasheet.ciiva.com/2454/pyu-r-int-thick-7-2454627.pdf?src-supplier=Digi-Key; Construction: Chip; JESD-609 Code: e3; Library Name: Altium Content Vault; Max Operating Temperature: 155°C; Min Operating Temperature: -55°C; Mount: Surface Mount; Number of Pins: 2; Package Shape: Rectangular; Package Style: SMTMeter; Packaging: Tape and Reel; Part Number: RC0603FR-07300RL; Pricing 1: 5000=0.00150, 50000=0.00140, 200000=0.00140, 625000=0.00130, 2000000=0.00120 (USD); Reach SVHC Compliant: Yes; Resistance: 300R; Resistor Type: FIXED RESISTOR; RoHS: Yes; RoHS Compliant: Yes; Size Code: 0603; Stock 1: 2680000; Supplier 1: Future Electronics; Supplier Part Number 1: 5366000; Technology: METAL GLAZE/THICK FILM; Terminal Finish: Nickel; Termination: Wraparound; Tolerance: 1%
R17 = CMP-009-00023-6: Chip Resistor, 100 KOhm, +/- 1%, 0.1 W, -55 to 155 degC, 0603 (1608 Metric); Manufacturer 1: Yageo; Manufacturer Part Number 1: RC0603FR-07100KL; Power: 100mW; Voltage Rating: 50V; Case/Package: 0603; Component Kind: Standard; Library Name: Renesas Global; Max Operating Temperature: 155°C; Min Operating Temperature: -55°C; Mounting Technology: SM; Pins: 2; RoHS Compliant: True; Tolerance: 1%; Value: 100K
R19 R20 = CMP-009-00014-6: Chip Resistor, 1 KOhm, +/-1%, 0.1 W, -55 to 155 degC, 0603 (1608 Metric); Manufacturer 1: Yageo; Manufacturer Part Number 1: RC0603FR-071KL; Power: 100mW; Tolerance: 1%; Voltage Rating: 50V; Case/Package: 0603; Component Kind: Standard; Library Name: Renesas Global; Max Operating Temperature: 155°C; Min Operating Temperature: -55°C; Mounting Technology: SM; Pins: 2; RoHS Compliant: True; Value: 1k
U1 = CMP-031-00055-1: GreenPAK Programmable Mixed-Signal Matrix; Manufacturer 1: Renesas Electronics; Case/Package: 20-Ld STQFN; Component Kind: Standard; Design Item ID: SLG47105V; HelpURL: https://www.dialog-semiconductor.com/sites/default/files/2021-04/SLG47105_ds_3v1.pdf; Library Name: Renesas Global; Manufacturer Part Number 1: SLG47105V; Source: GreenPAKs.SchLib; Supplier Link 1: https://www.dialog-semiconductor.com/products/greenpak/slg47105#tab-field_tab_content_order
U2 = CMP-031-00131-4: 500mA 20V Wide Input Voltage Range LDO Linear Regulator; Manufacturer 1: Renesas Electronics; Component Kind: Standard; Datasheet Link: https://www.renesas.com/us/en/document/dst/raa214250-datasheet?r=1558211; Design Item ID: RAA2142504GSP#HA0; Height: 1.7mm; Library Name: Renesas Global; Manufacturer Part Number 1: RAA2142504GSP#HA0; Mouser Part Number: 968-RAA2142504GSPHA0; Mouser Price/Stock: https://www.mouser.co.uk/ProductDetail/Renesas-Intersil/RAA2142504GSPHA0?qs=Li%252BoUPsLEnuwenCLbx7Yqg%3D%3D; Source: RAA214250.SchLib
U3 = CMP-004-000017-2: Digital Power Monitors Precision Digital Power Monitor with Real Time Alerts; Manufacturer 1: Renesas Electronics; Geometry.Height: 1mm; Component Kind: Standard; Datasheet Link: https://www.renesas.com/en-us/www/doc/datasheet/isl28025.pdf; Design Item ID: ISL28025FR12Z; Library Name: Renesas Global; Manufacturer Part Number 1: ISL28025FR12Z; Mouser Part Number: 968-ISL28025FR12Z; Mouser Price/Stock: https://www.mouser.co.uk/ProductDetail/Renesas-Intersil/ISL28025FR12Z?qs=55YtniHzbhBpOqIqa62hIg%3D%3D; Source: RAA211250 SYNC BUCK REGULATOR.SchLib
